# Altium schematic sheet LevelShifter.SchDoc
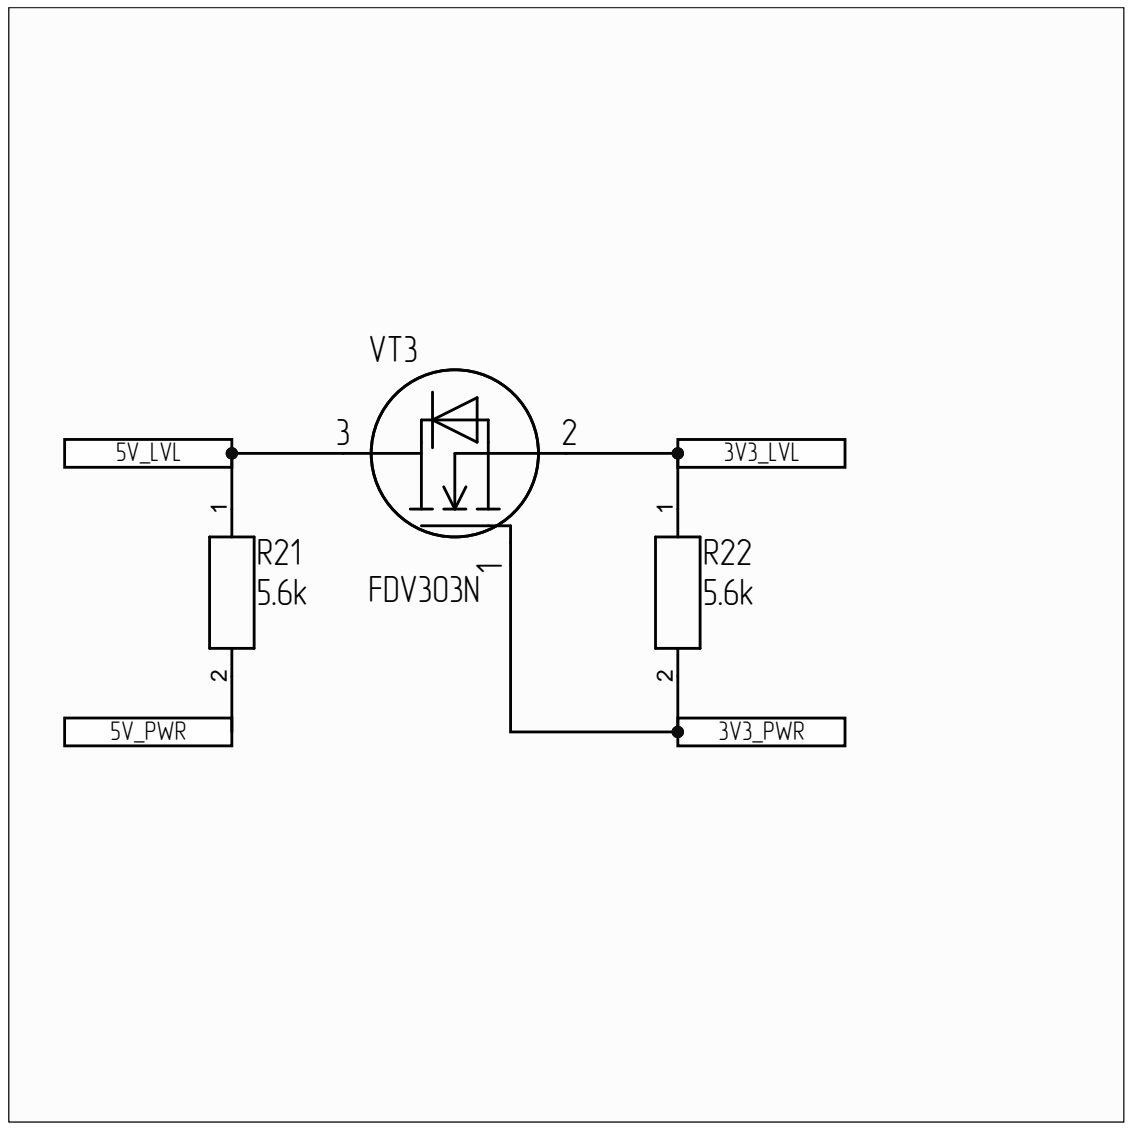
[diagram p0: LevelShifter.SchDoc]

## Parts
R21  value 5.6k  [0603]  (hidden comment: 0.1Вт 0603 5.6 кОм, 1%, Чип резистор (SMD))
R22  value 5.6k  [0603]  (hidden comment: 0.1Вт 0603 5.6 кОм, 1%, Чип резистор (SMD))
VT3  FDV303N, Транзистор, Digital FET, N-канал 25В 680мА [SOT-23]  [SOT-23-3]  (value not drawn)

## Ports
3V3_LVL, 3V3_PWR, 5V_LVL, 5V_PWR

## Nets
3V3_LVL: R22.1 VT3.2 ; port 3V3_LVL
3V3_PWR: R22.2 VT3.1 ; port 3V3_PWR
5V_LVL: R21.1 VT3.3 ; port 5V_LVL
5V_PWR: R21.2 ; port 5V_PWR

## Library data of the parts
R21 R22 = Resistor: Component Kind: Standard; HelpURL: https://www.chipdip.ru/product0/9000079730; Library Name: Resistors.IntLib; Zakaz: 0.1Вт 0603 5.6 кОм, 1%, Чип резистор (SMD)
VT3 = FDV303N: MOSFET N-CH 25V 0.68A SOT23-3; Current - Continuous Drain (Id) @ 25°C: 0.68A (Ta); FET Type: N-Channel; Power Dissipation (Max): 0.35W (Ta); Rds On (Max) @ Id, Vgs: 45mOhm @ 0.5A, 4.5V; Vgs (Max): ±8V; Vgs(th) (Max) @ Id: 0.65..1.5V; Case: SOT-23; Component Kind: Standard; Drain to Source Voltage (Vdss): 25 V; HelpURL: https://www.chipdip.ru/product/fdv303n; Operating Temperature: -55°C ~ 150°C (TJ); Technology: MOSFET (Metal Oxide); Type: FDV303N; Zakaz: FDV303N, Транзистор, Digital FET, N-канал 25В 680мА [SOT-23]; Library Name: Tranzistor.IntLib
